# Altium schematic sheet Power.SchDoc(Power)
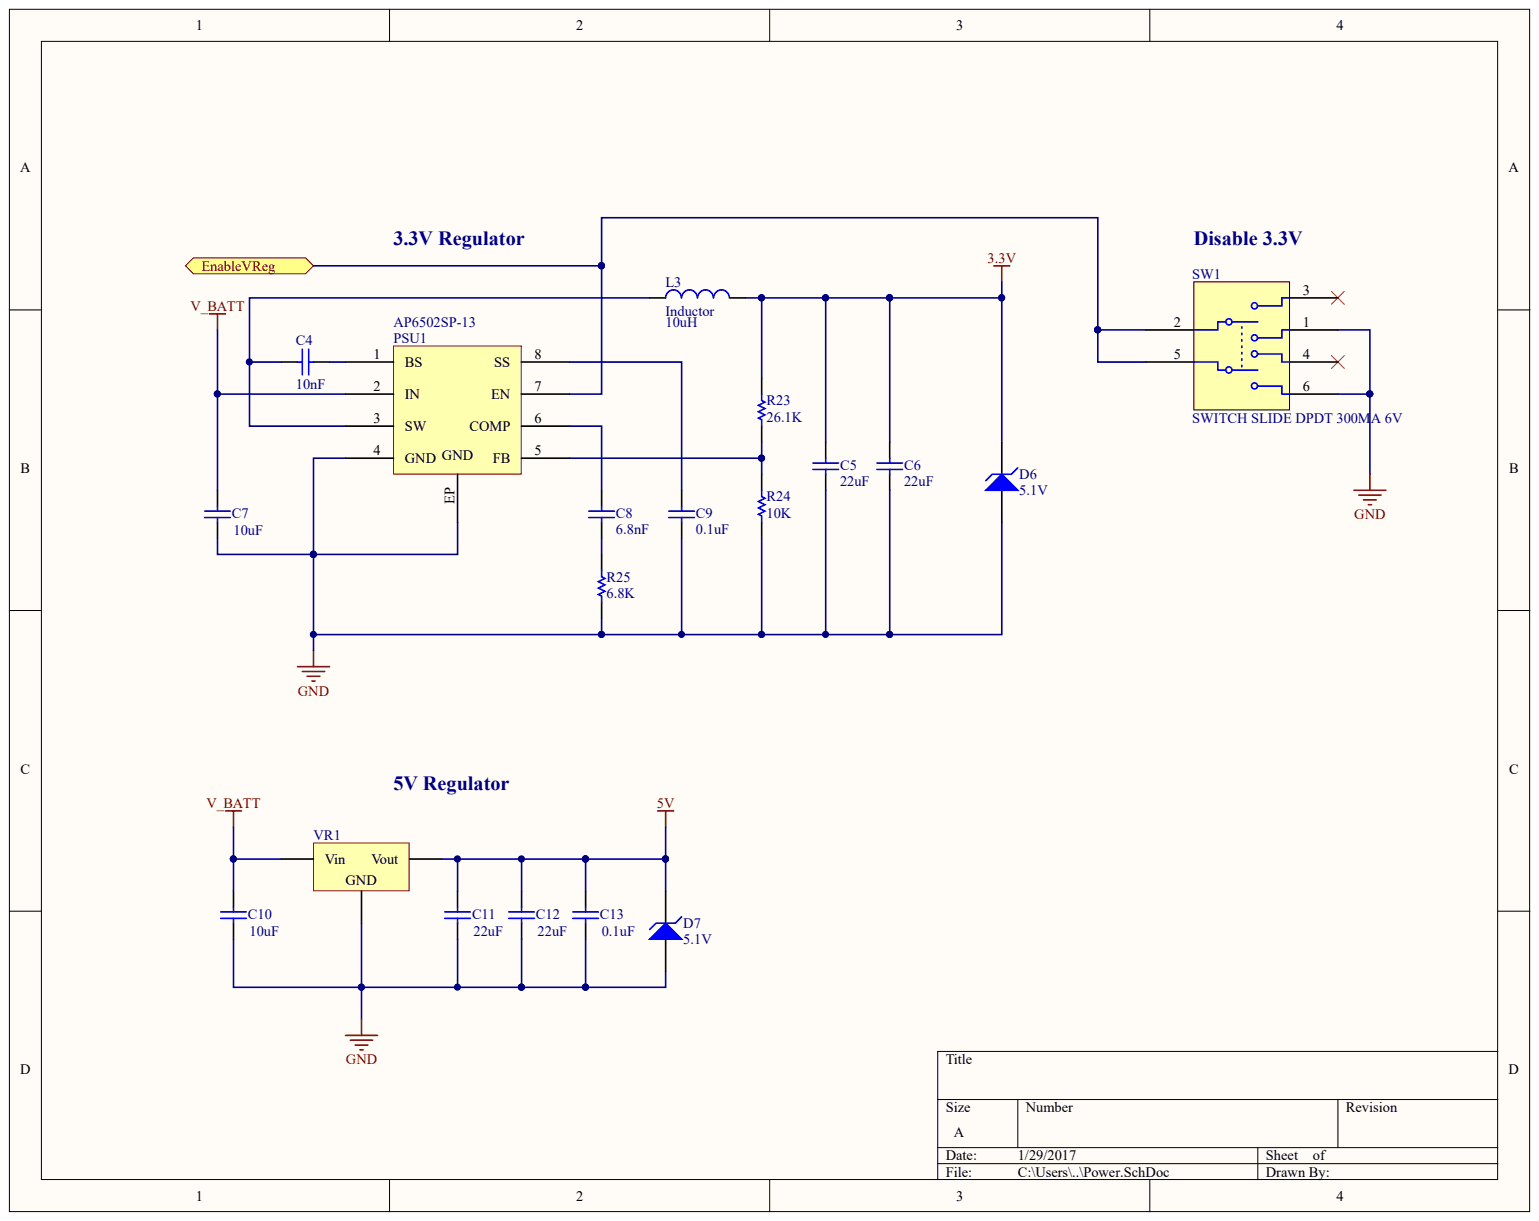
[diagram p0: Power.SchDoc]

## Parts
C4  value 10nF  (hidden comment: Cap_0805)
C5  value 22uF  (hidden comment: Cap_0805)
C6  value 22uF  (hidden comment: Cap_0805)
C7  value 10uF  (hidden comment: Cap_0805)
C8  value 6.8nF  (hidden comment: Cap_0805)
C9  value 0.1uF  (hidden comment: Cap_0805)
C10  value 10uF  (hidden comment: Cap_0805)
C11  value 22uF  (hidden comment: Cap_0805)
C12  value 22uF  (hidden comment: Cap_0805)
C13  value 0.1uF  (hidden comment: Cap_0805)
D6  5.1V
D7  5.1V
L3  Inductor  value 10uH
PSU1  AP6502SP-13
R23  value 26.1K  (hidden comment: Res 0805)
R24  value 10K  (hidden comment: Res 0805)
R25  value 6.8K  (hidden comment: Res 0805)
SW1  SWITCH SLIDE DPDT 300MA 6V
VR1  Volt Reg 5v  (value not drawn)

## Ports
ENABLEVREG

## Nets
3.3V: C5.2 C6.2 D6.2 L3.2 R23.2
5V: C11.2 C12.2 C13.2 D7.2 VR1.3
ENABLEVREG: PSU1.7(EN) SW1.2 SW1.5 ; port ENABLEVREG
GND: C5.1 C6.1 C7.1 C9.1 C10.1 C11.1 C12.1 C13.1 D6.1 D7.1 PSU1.4(GND) PSU1.EP R24.2 R25.2 SW1.1 SW1.6 VR1.2
V_BATT: C7.2 C10.2 PSU1.2(IN) VR1.1
NetC4_1: C4.1 PSU1.1(BS)
NetC4_2: C4.2 L3.1 PSU1.3(SW)
NetC8_1: C8.1 R25.1
NetC8_2: C8.2 PSU1.6(COMP)
NetC9_2: C9.2 PSU1.8(SS)
NetPSU1_5: PSU1.5(FB) R23.1 R24.1

Not connected: SW1 3 4

## Library data of the parts
L3 = (no symbol name): Code_IEC: 1005; Published: 8-Jun-2000; LatestRevisionDate: 29-May-2009; LatestRevisionNote: IPC-7351 Footprint Added.; PackageReference: 0402-A; PackageDescription: Chip Inductor; Code_JEITA: 0402; Publisher: Altium Limited
